# Altium schematic sheet Schematic.SchDoc
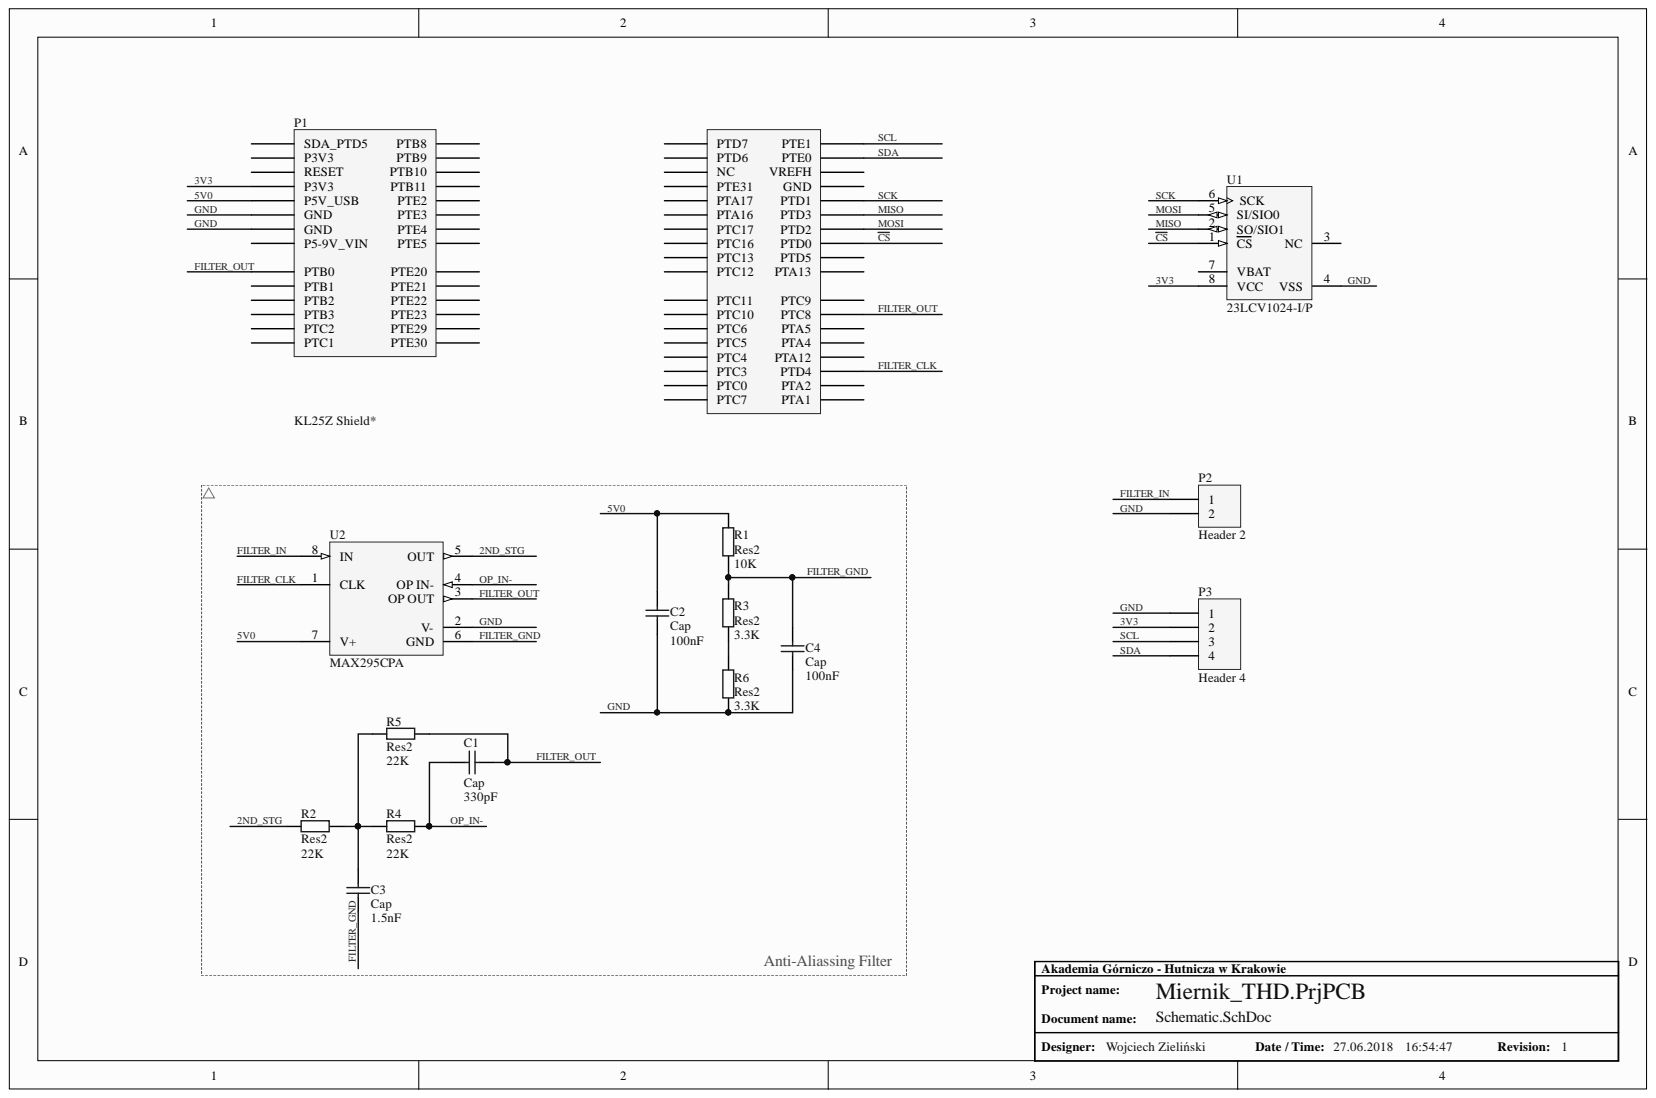
[diagram p0: Schematic.SchDoc]

## Parts
C1  Cap  value 330pF  [RAD-0.1]
C2  Cap  value 100nF  [RAD-0.1]
C3  Cap  value 1.5nF  [RAD-0.1]
C4  Cap  value 100nF  [RAD-0.1]
P1  KL25Z Shield*  [Freedom KL25Z Headerarray]
P2  Header 2  [HDR1X2]
P3  Header 4  [HDR1X4]
R1  Res2  value 10K  [AXIAL-0.4]
R2  Res2  value 22K  [AXIAL-0.4]
R3  Res2  value 3.3K  [AXIAL-0.4]
R4  Res2  value 22K  [AXIAL-0.4]
R5  Res2  value 22K  [AXIAL-0.4]
R6  Res2  value 3.3K  [AXIAL-0.4]
U1  23LCV1024-I/P  [MCHP-PDIP-P8]
U2  MAX295CPA  [MAXM-P8-2]

## Nets
2ND_STG: R2.1 U2.5(OUT)
3V3: P1.7(P3V3) P3.2 U1.8(VCC)
5V0: C2.2 P1.9(P5V_USB) R1.2 U2.7(V+)
~{CS}: P1.44(PTD0) U1.1(CS)
FILTER_CLK: P1.60(PTD4) U2.1(CLK)
FILTER_GND: C3.1 C4.2 R1.1 R3.2 U2.6(GND)
FILTER_IN: P2.1 U2.8(IN)
FILTER_OUT: C1.2 P1.17(PTB0) P1.52(PTC8) R5.2 U2.3(OP_OUT)
GND: C2.1 C4.1 P1.11(GND) P1.13(GND) P2.2 P3.1 R6.1 U1.4(VSS) U2.2(V-)
MISO: P1.40(PTD3) U1.2(SO/SIO1)
MOSI: P1.42(PTD2) U1.5(SI/SIO0)
OP_IN-: C1.1 R4.2 U2.4(OP_IN-)
SCK: P1.38(PTD1) U1.6(SCK)
SCL: P1.30(PTE1) P3.3
SDA: P1.32(PTE0) P3.4
NetC3_2: C3.2 R2.2 R4.1 R5.1
NetR3_1: R3.1 R6.2

Not connected: P1 1(SDA_PTD5) 2 3(P3V3) 4 5(RESET) 6 8 10 12 14 15(P5-9V_VIN) 16 18 19(PTB1) 20 21(PTB2) 22 23(PTB3) 24 25(PTC2) 26 27(PTC1) 28 29 31 33 34(VREFH) 35 36(GND) 37 39 41 43 45 46(PTD5) 47 48(PTA13) 49 50(PTC9) 51 53 54(PTA5) 55 56(PTA4) 57 58(PTA12) 59 61 62(PTA2) 63 64(PTA1); U1 3(NC) 7(VBAT)

## Library data of the parts
C1-C4 = Cap: Capacitor; Component Kind: Standard; LatestRevisionDate: 17-Jul-2002; LatestRevisionNote: Re-released for DXP Platform.; Library Name: Miscellaneous Devices.IntLib; PackageDescription: Radial Cap, Thru-Hole; 2 Leads; 0.3 in Pin Spacing; PackageReference: RAD-0.3; Published: 8-Jun-2000; Signal Integrity: Cap; Simulation: CAP; Publisher: Altium Limited
P1 = Freedom KL25Z Header: FRDM KL25Z Shield; Component Kind: Standard; Library Name: FreedomKL25Z.SchLib
P2 = Header 2: Header, 2-Pin; Component Kind: Standard; LatestRevisionDate: 17-Jul-2002; LatestRevisionNote: Re-released for DXP Platform.; Library Name: Miscellaneous Connectors.IntLib; Signal Integrity: Connector; Publisher: Altium Limited
P3 = Header 4: Header, 4-Pin; Component Kind: Standard; LatestRevisionDate: 17-Jul-2002; LatestRevisionNote: Re-released for DXP Platform.; Library Name: Miscellaneous Connectors.IntLib; Signal Integrity: Connector; Publisher: Altium Limited
R1-R6 = Res2: Resistor; Component Kind: Standard; LatestRevisionDate: 17-Jul-2002; LatestRevisionNote: Re-released for DXP Platform.; Library Name: Miscellaneous Devices.IntLib; PackageDescription: Axial Device, Thru-Hole; 2 Leads; 0.4 in Pin Spacing; PackageReference: AXIAL-0.4; Published: 8-Jun-2000; Signal Integrity: Res; Simulation: RESISTOR; Publisher: Altium Limited
U1 = 23LCV1024-I/P: 1024K bits SPI Serial SRAM with Battery Backup and SDI Interface, 2.5 to 5.5 V, 20 MHz, -40 to 85 degC, 8-pin PDIP (P8), Tube; Manufacturer: Microchip; Component Kind: Standard; ComponentLink1Description: Manufacturer URL; ComponentLink1URL: http://www.microchip.com; ComponentLink2Description: Datasheet; ComponentLink2URL: http://ww1.microchip.com/downloads/en/DeviceDoc/25156A.pdf; ComponentLink3Description: Package Specification; ComponentLink3URL: http://www.microchip.com/stellent/groups/techpub_sg/documents/packagingspec/en012702.pdf; ComponentLink4Description: Product URL; ComponentLink4URL: http://www.microchip.com/23LCV1024; DatasheetVersion: Rev. A; Library Name: Microchip Serial SRAM.IntLib; Mounting Technology: Through Hole; PackageDescription: 8-Pin Plastic Dual In-Line, Row Spacing 7.62 mm, 9.5 x 6.6 mm Body, 2.54 mm Pitch; PackageReference: PDIP-P8; PackageVersion: C04-018B; PartNumber: 23LCV1024-I/P; Supplier 1: Digi-Key; Supplier 2: Newark; Supplier 3: Mouser; Supplier Part Number 1: 23LCV1024-I/P-ND; Supplier Part Number 2: 94T8210; Supplier Part Number 3: 579-23LCV1024-I/P
U2 = MAX295CPA: 8th-Order Switched Capacitor Lowpass Filter, Butterworth, Clock Tunable, 0 to 70 degC, 8-Pin DIP (P8-2); Generic Part Number: MAX295; Filter Sections: 1; Order Per Filter Section: 8; Code_JEDEC: MS001-XX; Component Kind: Standard; ComponentLink1Description: Manufacturer URL; ComponentLink1URL: http://www.maximintegrated.com/; ComponentLink2Description: Datasheet; ComponentLink2URL: http://datasheets.maximintegrated.com/en/ds/MAX291-MAX296.pdf; ComponentLink3Description: Package Specification; ComponentLink3URL: http://pdfserv.maximintegrated.com/package_dwgs/21-0043.PDF; DatasheetVersion: Rev. 5; Filter Characteristic: Butterworth; Filter Class: Switched Cap; Filter Type: Lowpass; Library Name: Maxim Analog Filters.IntLib; Mounting Technology: Through Hole; PackageDescription: 8-Pin Plastic Dual-In-Line, Narrow (0.3in) (P8-2), Body 9.375 x 6.985 mm, Pitch 2.54 mm; PackageReference: P8-2; PackageVersion: Rev. E, 04/2011; PartNumber: MAX295CPA; Program Method: Clock; Supplier 1: Mouser; Supplier Part Number 1: N/A
